# Altium schematic sheet INCLINE.SchDoc
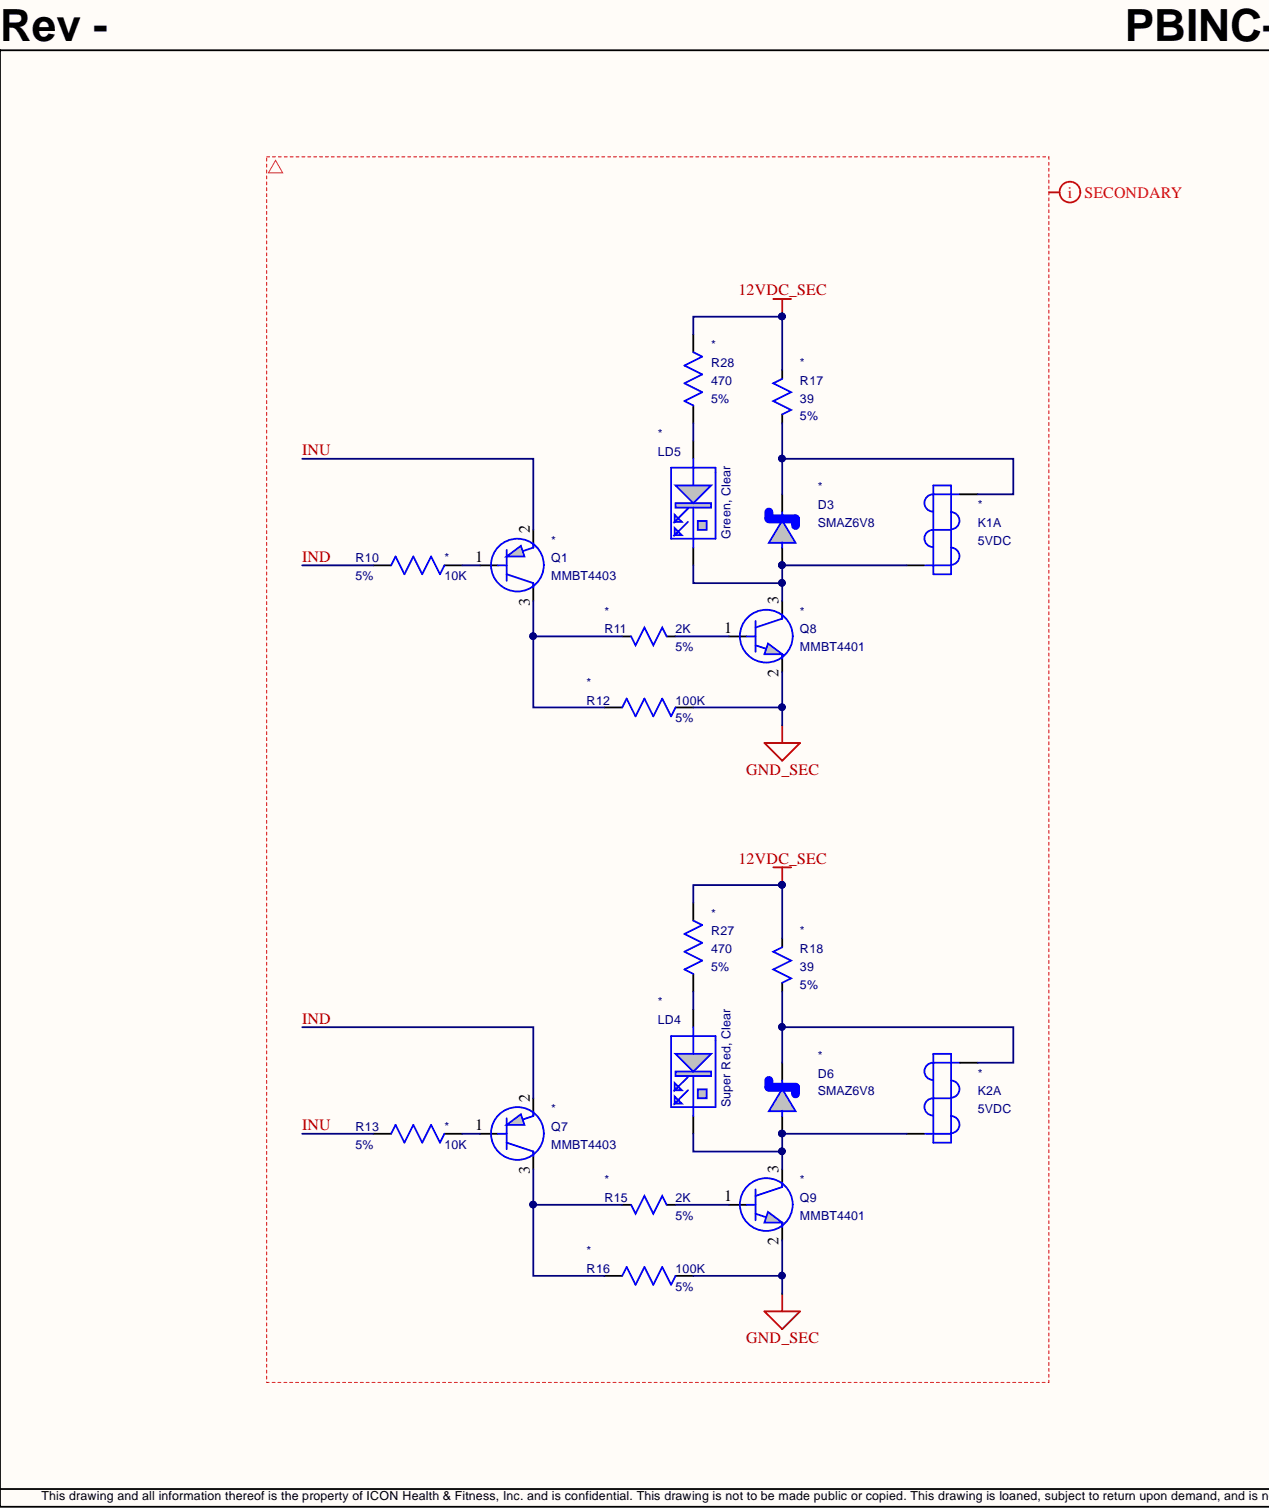
[diagram p0: INCLINE.SchDoc, left]
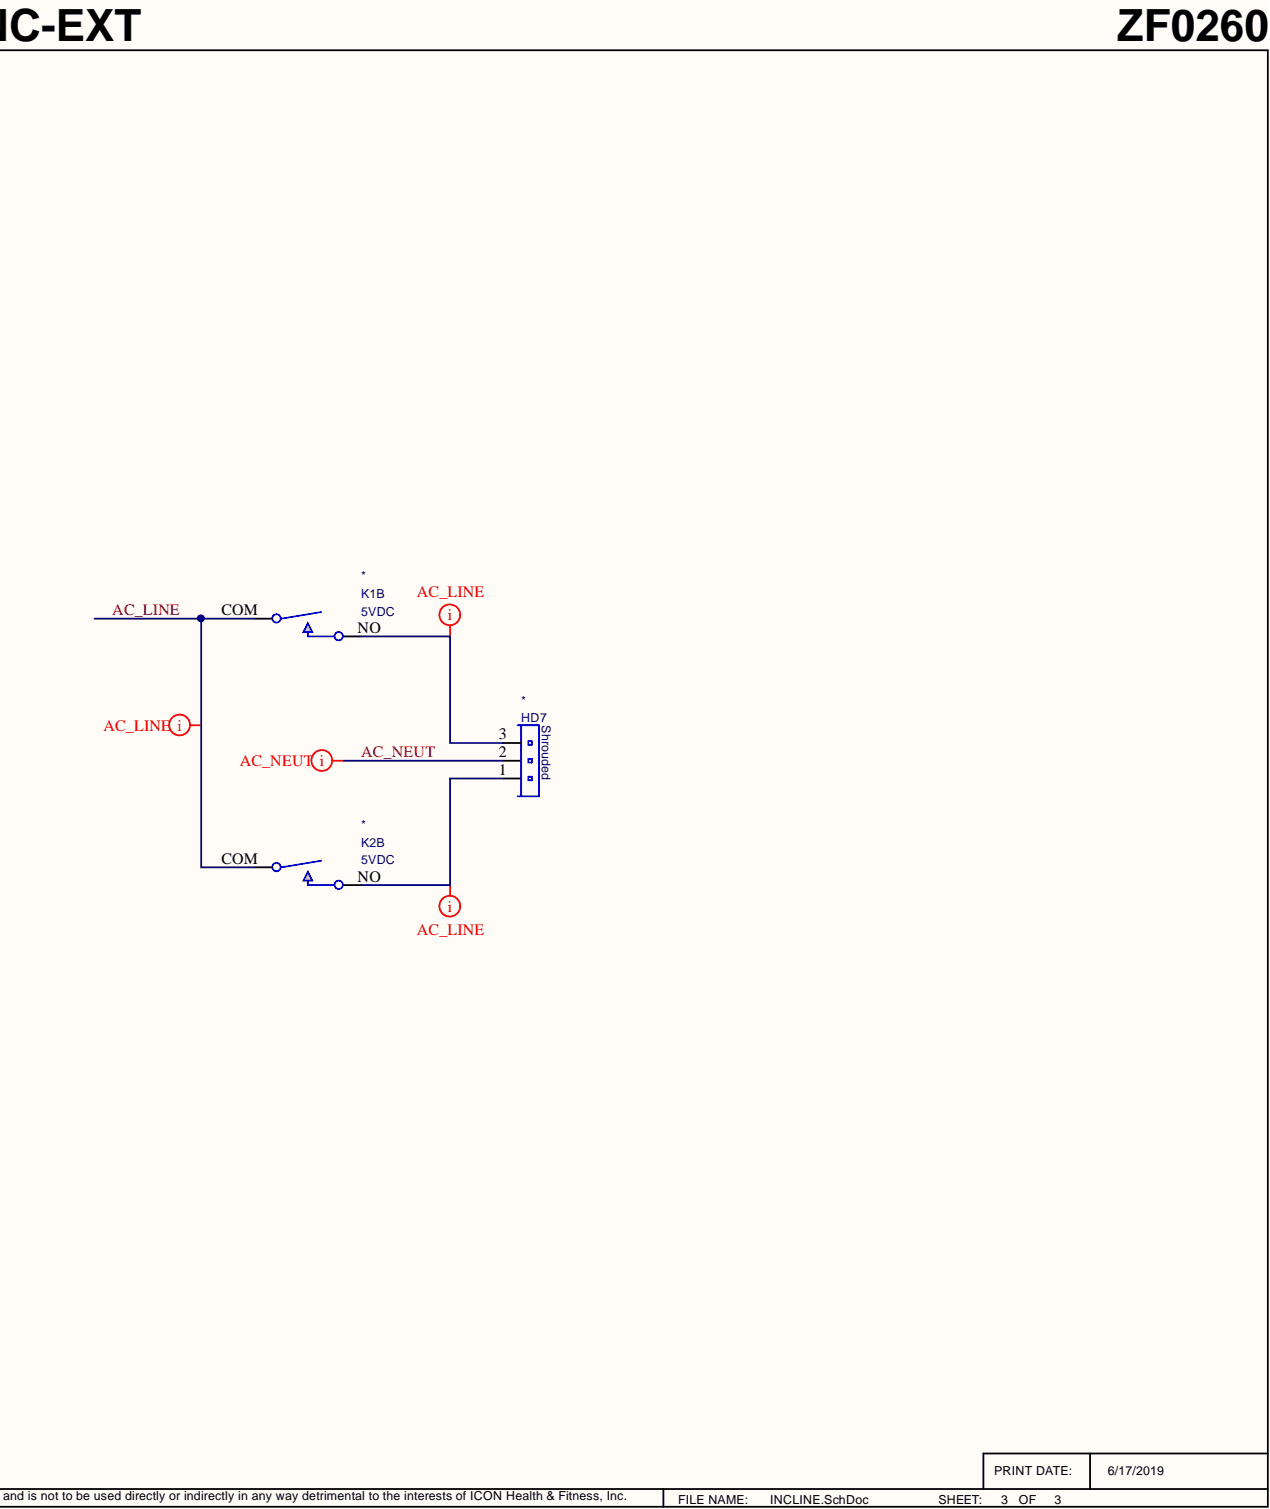
[diagram p1: INCLINE.SchDoc, right]

## Parts
D3  SMAZ6V8  [SMA]
D6  SMAZ6V8  [SMA]
HD7  HeaderType: Shrouded  [HDR_156MIL_3PIN_SHRD_MTA]  (hidden comment: HDR_3PIN)
K1  Voltage: 5VDC  [RELAY_RT_SPST_NO]  (hidden comment: RELAY_SPST_NO)  (parts A,B)
K2  Voltage: 5VDC  [RELAY_RT_SPST_NO]  (hidden comment: RELAY_SPST_NO)  (parts A,B)
LD4  Color: Super Red, Clear  [0603_LED]  (hidden comment: 0603_RED)
LD5  Color: Green, Clear  [0603_LED]  (hidden comment: 0603_GREEN)
Q1  value MMBT4403  [SOT23-3]  (hidden comment: TRANSISTOR_PNP_SOT23)
Q7  value MMBT4403  [SOT23-3]  (hidden comment: TRANSISTOR_PNP_SOT23)
Q8  value MMBT4401  [SOT23-3]  (hidden comment: TRANSISTOR_NPN_SOT23)
Q9  value MMBT4401  [SOT23-3]  (hidden comment: TRANSISTOR_NPN_SOT23)
R10  value 10K  Tolerance: 5%  [0603]  (hidden comment: RESISTOR_SMT_5%)
R11  value 2K  Tolerance: 5%  [0603]  (hidden comment: RESISTOR_SMT)
R12  value 100K  Tolerance: 5%  [0603]  (hidden comment: RESISTOR_SMT_5%)
R13  value 10K  Tolerance: 5%  [0603]  (hidden comment: RESISTOR_SMT_5%)
R15  value 2K  Tolerance: 5%  [0603]  (hidden comment: RESISTOR_SMT)
R16  value 100K  Tolerance: 5%  [0603]  (hidden comment: RESISTOR_SMT_5%)
R17  value 39  Tolerance: 5%  [2010]  (hidden comment: RESISTOR_SMT)
R18  value 39  Tolerance: 5%  [2010]  (hidden comment: RESISTOR_SMT)
R27  value 470  Tolerance: 5%  [0603]  (hidden comment: RESISTOR_SMT_5%)
R28  value 470  Tolerance: 5%  [0603]  (hidden comment: RESISTOR_SMT_5%)

## Nets
12VDC_SEC: R17.1 R18.1 R27.1 R28.1
GND_SEC: Q8.2 Q9.2 R12.2 R16.2
AC_LINE: K1.COM K2.COM
AC_NEUT: HD7.2
IND: Q7.2 R10.2
INU: Q1.2 R13.2
NetD3_1: D3.1 K1.COIL_2 R17.2
NetD3_2: D3.2 K1.COIL_1 LD5.1 Q8.3
NetD6_1: D6.1 K2.COIL_2 R18.2
NetD6_2: D6.2 K2.COIL_1 LD4.1 Q9.3
NetHD7_1: HD7.1 K2.NO
NetHD7_3: HD7.3 K1.NO
NetLD4_2: LD4.2 R27.2
NetLD5_2: LD5.2 R28.2
NetQ1_1: Q1.1 R10.1
NetQ1_3: Q1.3 R11.1 R12.1
NetQ7_1: Q7.1 R13.1
NetQ7_3: Q7.3 R15.1 R16.1
NetQ8_1: Q8.1 R11.2
NetQ9_1: Q9.1 R15.2
N00007:

## Library data of the parts
D3 D6 = 14977: Diode, SMAZ6V8, Zener, 6.8V, SMAZ, Diode Inc: SMAZ6V8; Database Table Name: AltiumComponents; IDF_COMP: 1; PackageOutlineLayer: PackageOutline; Wattage: 1W; ComponentHeight: 103mil; Component Kind: Standard; ComponentLink4Description: PDM Parts Maintenance; ComponentLink4URL: https://pdm.iconfitness.com/PDM/EBOM/PartsMaintenance/PartsMaintenance.aspx?Editplidx=14977; ComponentType: Diode; Cost: 0.05; DiodeType: Zener; ModifyDate: 08/27/2007 16:11:30; Package: SMAZ; plIDX: 14977; Value: SMAZ6V8; Vendor: Diode Inc: SMAZ6V8; Voltage: 6.8V; CheckedBy: M.Thov; Datasheet: G:\accel_lib\Datasheets\SMAZ5v1_39.pdf; HelpURL: G:\accel_lib\Datasheets\SMAZ5v1_39.pdf; Library Name: bome.DbLib
HD7 = 19370: Header, 3 Pins, 0.156 in., Shrouded, TE: 2-647127-3 or Equivalent; Database Table Name: AltiumComponents; Component Kind: Standard; ComponentLink4Description: PDM Parts Maintenance; ComponentLink4URL: https://pdm.iconfitness.com/PDM/EBOM/PartsMaintenance/PartsMaintenance.aspx?Editplidx=19370; ComponentType: Header; Cost: 0.18; LeadSpacing: 0.156 in.; Pins: 3; plIDX: 19370; Vendor: TE: 2-647127-3 or Equivalent; LastUpdatedBy: Mike Tyger; Library Name: bome.DbLib
K1 K2 = 265235: Relay, 5VDC, PCB Mount, 10A, SPST-NO, TE:RTB14005F; Song Chaun:845HN-1A-F-S-5VDC; Panasonic:JW1aFSN-DC5V; Tianbo:TRA1 L-05VDC-S-H; Database Table Name: AltiumComponents; Amperage: 10A; Circuit: SPST-NO; Component Kind: Standard; ComponentLink4Description: PDM Parts Maintenance; ComponentLink4URL: https://pdm.iconfitness.com/PDM/EBOM/PartsMaintenance/PartsMaintenance.aspx?Editplidx=265235; ComponentType: Relay; Cost: 0.4; Package: PCB Mount; plIDX: 265235; Vendor: TE:RTB14005F; Song Chaun:845HN-1A-F-S-5VDC; Panasonic:JW1aFSN-DC5V; Tianbo:TRA1 L-05VDC-S-H; CreatedBy: Mike Tyger; LastUpdatedBy: Mike Tyger; Library Name: bome.DbLib
LD4 = 9506: LED, Super Red, Clear, Rectangle, Diffused, 0603, Vendor: Lite-on, LTST-C190KRKT or equivalent; Database Table Name: AltiumComponents; IDF_COMP: 1; PackageOutlineLayer: PackageOutline; ComponentHeight: 32mil; Component Kind: Standard; ComponentLink4Description: PDM Parts Maintenance; ComponentLink4URL: https://pdm.iconfitness.com/PDM/EBOM/PartsMaintenance/PartsMaintenance.aspx?Editplidx=9506; ComponentType: LED; Cost: 0.015; LEDType: Diffused; ModifyDate: 02/08/2007 16:42:04; Package: 0603; plIDX: 9506; Shape: Rectangle; Vendor: Lite-on; VendorNumber: LTST-C190KRKT or equivalent; CheckedBy: Elton John; Datasheet: G:\accel_lib\datasheets\LTST-C190KRKT.pdf; HelpURL: G:\accel_lib\datasheets\LTST-C190KRKT.pdf; LastUpdatedBy: Mike Tyger; Library Name: bome.DbLib
LD5 = 9505: LED, Green, Clear, Rectangle, Diffused, 0603, Vendor: Lite-On, LTST-C190KGKT or Equivalent; Database Table Name: AltiumComponents; Component Kind: Standard; ComponentLink4Description: PDM Parts Maintenance; ComponentLink4URL: https://pdm.iconfitness.com/PDM/EBOM/PartsMaintenance/PartsMaintenance.aspx?Editplidx=9505; ComponentType: LED; Cost: 0.022; LEDType: Diffused; Package: 0603; plIDX: 9505; Shape: Rectangle; Vendor: Lite-On; VendorNumber: LTST-C190KGKT or Equivalent; CheckedBy: Richard Simmons; LastUpdatedBy: Mike Tyger; Library Name: bome.DbLib
Q1 Q7 = 265444: Transistor, MMBT4403, 40V, SOT23-3, 500mA, PNP, Transistor, On Semi: MMBT4403LT1; Database Table Name: AltiumComponents; Amperage: 500mA; ChipType: Transistor; Component Kind: Standard; ComponentLink4Description: PDM Parts Maintenance; ComponentLink4URL: https://pdm.iconfitness.com/PDM/EBOM/PartsMaintenance/PartsMaintenance.aspx?Editplidx=265444; ComponentType: Transistor; Cost: 0.0094; Package: SOT23-3; plIDX: 265444; TransistorType: PNP; Vendor: On Semi: MMBT4403LT1; Voltage: 40V; CheckedBy: gdeguzman; CreatedBy: Mike Tyger; LastUpdatedBy: Mike Tyger; Library Name: bome.DbLib
Q8 Q9 = 265442: Transistor, MMBT4401, 40V, SOT23-3, 500mA, NPN, Transistor, On Semi: MMBT4401LT1; Database Table Name: AltiumComponents; Amperage: 500mA; ChipType: Transistor; Component Kind: Standard; ComponentLink4Description: PDM Parts Maintenance; ComponentLink4URL: https://pdm.iconfitness.com/PDM/EBOM/PartsMaintenance/PartsMaintenance.aspx?Editplidx=265442; ComponentType: Transistor; Cost: 0.0094; Package: SOT23-3; plIDX: 265442; TransistorType: NPN; Vendor: On Semi: MMBT4401LT1; Voltage: 40V; CheckedBy: gdeguzman; CreatedBy: Mike Tyger; LastUpdatedBy: Mike Tyger; Library Name: bome.DbLib
R10 R13 = 265232: Resistor, 10K, ThickFilm, 5%, 0603, 1/10W, Any; Database Table Name: AltiumComponents; Component Kind: Standard; ComponentLink4Description: PDM Parts Maintenance; ComponentLink4URL: https://pdm.iconfitness.com/PDM/EBOM/PartsMaintenance/PartsMaintenance.aspx?Editplidx=265232; ComponentType: Resistor; Composition: ThickFilm; Cost: 0.001; Package: 0603; plIDX: 265232; Vendor: Any; Wattage: 1/10W; CheckedBy: gdeguzman; CreatedBy: Mike Tyger; LastUpdatedBy: Mike Tyger; Library Name: bome.DbLib
R11 R15 = 20783: Resistor, 2K, ThickFilm, 5%, 0603, 1/10W, Any; Database Table Name: AltiumComponents; Component Kind: Standard; ComponentLink4Description: PDM Parts Maintenance; ComponentLink4URL: https://pdm.iconfitness.com/PDM/EBOM/PartsMaintenance/PartsMaintenance.aspx?Editplidx=20783; ComponentType: Resistor; Composition: ThickFilm; Cost: .0003; Package: 0603; plIDX: 20783; Vendor: Any; Wattage: 1/10W; LastUpdatedBy: Mike Tyger; Library Name: bome.DbLib
R12 R16 = 265231: Resistor, 100K, ThickFilm, 5%, 0603, 1/10W, Any; Database Table Name: AltiumComponents; Component Kind: Standard; ComponentLink4Description: PDM Parts Maintenance; ComponentLink4URL: https://pdm.iconfitness.com/PDM/EBOM/PartsMaintenance/PartsMaintenance.aspx?Editplidx=265231; ComponentType: Resistor; Composition: ThickFilm; Cost: 0.001; Package: 0603; plIDX: 265231; Vendor: Any; Wattage: 1/10W; CheckedBy: gdeguzman; CreatedBy: Mike Tyger; LastUpdatedBy: Mike Tyger; Library Name: bome.DbLib
R17 R18 = 19252: Resistor, 39, ThickFilm, 5%, 2010, 1/2W, Any; Database Table Name: AltiumComponents; Component Kind: Standard; ComponentLink4Description: PDM Parts Maintenance; ComponentLink4URL: https://pdm.iconfitness.com/PDM/EBOM/PartsMaintenance/PartsMaintenance.aspx?Editplidx=19252; ComponentType: Resistor; Composition: ThickFilm; Cost: 0.001; Package: 2010; plIDX: 19252; Vendor: Any; Wattage: 1/2W; LastUpdatedBy: Mike Tyger; Library Name: bome.DbLib
R27 R28 = 265467: Resistor, 470, ThickFilm, 5%, 0603, 1/10W, Any; Database Table Name: AltiumComponents; Component Kind: Standard; ComponentLink4Description: PDM Parts Maintenance; ComponentLink4URL: https://pdm.iconfitness.com/PDM/EBOM/PartsMaintenance/PartsMaintenance.aspx?Editplidx=265467; ComponentType: Resistor; Composition: ThickFilm; Cost: 0.001; Package: 0603; plIDX: 265467; Vendor: Any; Wattage: 1/10W; CheckedBy: gdeguzman; CreatedBy: Mike Tyger; LastUpdatedBy: Mike Tyger; Library Name: bome.DbLib
